# Altium schematic sheet Sheet2.SchDoc(Sheet2)
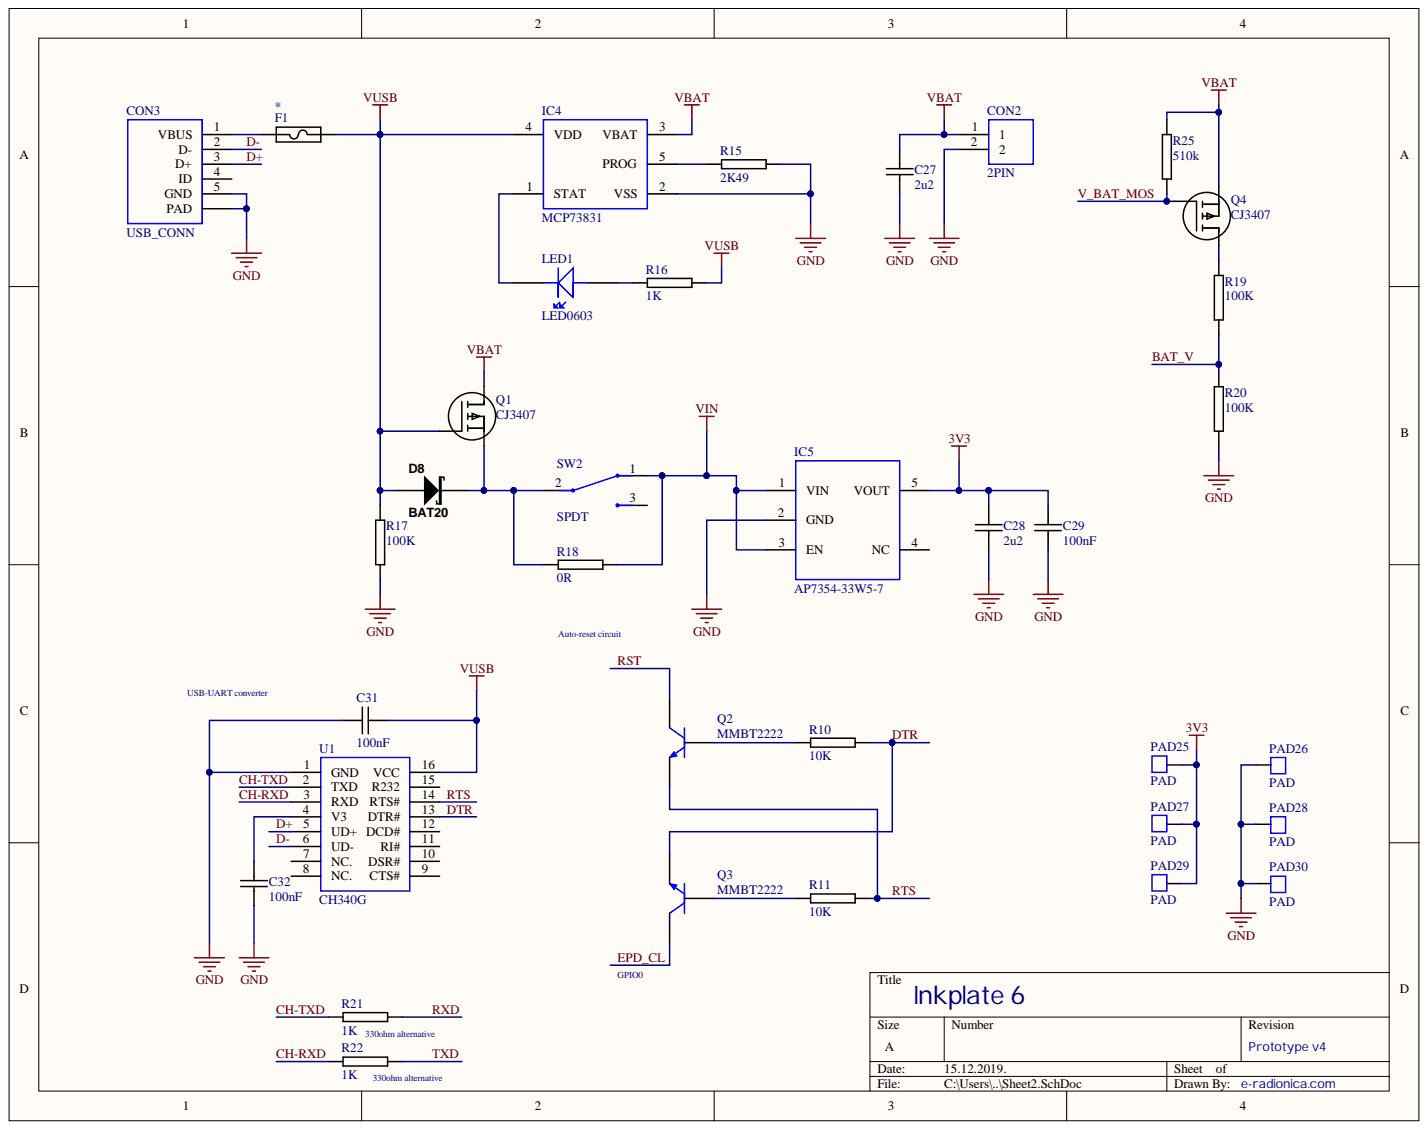
[diagram p0: Sheet2.SchDoc]

## Parts
C27  2u2  [0603C-2U2]
C28  2u2  [0603C-2U2]
C29  100nF  [0603C-GENERIC]
C31  100nF  [0603C-GENERIC]
C32  100nF  [0603C-GENERIC]
CON2  2PIN  [JST_SMD_2P]
CON3  USB_CONN  [USB_CONN]
D8  BAT20  [SOD323]
F1  (no value)
IC4  MCP73831  [SOT23-5_MCP]
IC5  AP7354-33W5-7  [SOT23-5_SE5218]
LED1  LED0603  [LED0603]
PAD25  PAD  [PAD4]
PAD26  PAD  [PAD4]
PAD27  PAD  [PAD4]
PAD28  PAD  [PAD4]
PAD29  PAD  [PAD4]
PAD30  PAD  [PAD4]
Q1  CJ3407  [SOT23-GDS]
Q2  MMBT2222  [SOT95P237X110-3N]
Q3  MMBT2222  [SOT95P237X110-3N]
Q4  CJ3407  [SOT23-GDS]
R10  10K  [0603R-10K]
R11  10K  [0603R-10K]
R15  2K49  [0603R-GENERIC]
R16  1K  [0603R-1k]
R17  100K  [0603R-100K]
R18  0R  [0603R-0R]
R19  100K  [0603R-100K]
R20  100K  [0603R-100K]
R21  1K  [0603R-1k]
R22  1K  [0603R-1k]
R25  510k  [0603R-GENERIC]
SW2  SPDT  [SPDT_SW]
U1  CH340G  [SOP16]

## Nets
3V3: C28.1 C29.1 IC5.5(VOUT) PAD25.1 PAD27.1 PAD29.1
GND: C27.2 C28.2 C29.2 C31.1 C32.2 CON2.2 CON3.5(GND) CON3.6(PAD) IC4.2(VSS) IC5.2(GND) PAD26.1 PAD28.1 PAD30.1 R15.2 R17.2 R20.2 U1.1(GND)
VBAT: C27.1 CON2.1 IC4.3(VBAT) Q1.D Q4.S R25.1
VIN: IC5.1(VIN) IC5.3(EN) R18.2 SW2.1
VUSB: C31.2 D8.A F1.2 IC4.4(VDD) Q1.G R16.2 R17.1 U1.16(VCC)
BAT_V: R19.2 R20.1
CH-RXD: R22.1 U1.3(RXD)
CH-TXD: R21.1 U1.2(TXD)
D+: CON3.3(D+) U1.5(UD+)
D-: CON3.2(D-) U1.6(UD-)
DTR: Q3.2 R10.2 U1.13(DTR#)
EPD_CL: Q3.3
RST: Q2.3
RTS: Q2.2 R11.2 U1.14(RTS#)
RXD: R21.2
TXD: R22.2
V_BAT_MOS: Q4.G R25.2
NetC32_1: C32.1 U1.4(V3)
NetCON3_1: CON3.1(VBUS) F1.1
NetD8_K: D8.K Q1.S R18.1 SW2.2
NetIC4_1: IC4.1(STAT) LED1.K
NetIC4_5: IC4.5(PROG) R15.1
NetLED1_A: LED1.A R16.1
NetQ2_1: Q2.1 R10.1
NetQ3_1: Q3.1 R11.1
NetQ4_D: Q4.D R19.1

Not connected: CON3 4(ID); IC5 4(NC); SW2 3; U1 7(NC.) 8(NC.) 9(CTS#) 10(DSR#) 11(RI#) 12(DCD#) 15(R232)

## Library data of the parts
C27 C28 = 0603C-2U2-10V-X5R-TDK: CAPACITOR 0603 CERAMIC; Manufacturer Part Number: C1608X5R1A225K; Manufacturer: TDK Corporation; Reel: C11; Supplier Part Number 1: 445-5166-2-ND; Supplier 1: Digi-Key; Library Name: vh_altium_library.intLib; Component Kind: Standard
C29 C31 C32 = 0603C-100NF-25V-X7R-TDK: CAPACITOR 0603 CERAMIC; Manufacturer Part Number: C1608X7R1E104K; Manufacturer: TDK Corporation; Reel: C12; Supplier Part Number 1: 445-1316-2-ND; Supplier 1: Digi-Key; Library Name: vh_altium_library.intLib; Component Kind: Standard
CON2 = JST_SMD_2P: Component Kind: Standard; Library Name: eink_library.IntLib
CON3 = USB_CONN: U-F-M5DD-Y-L; Component Kind: Standard; Library Name: eink_library.IntLib
D8 = BAT20-SOD323: Schottky Diode SOD323 1A 23V; Supplier Part Number 1: 497-3381-2-ND; Supplier 1: Digi-Key; Library Name: vh_altium_library.intLib; Component Kind: Standard
F1 = FUSE-GENERIC: GENERIC FUSE; Library Name: vh_altium_library.intLib; Component Kind: Standard
Q1 Q4 = BSS84: P CHANNEL MOSFET; Manufacturer Part Number: BSS84P L6327; Manufacturer: Infineon Technologies; Vgs(th) (Max) @ Id: 2V @ 20µA; Reel: Q11; Rds On (Max) @ Id, Vgs: 8 Ohm @ 170mA, 10V; Power - Max: 360mW; Input Capacitance (Ciss) @ Vds: 19pF @ 25V; Gate Charge (Qg) @ Vgs: 1.5nC @ 10V; FET Type: MOSFET P-Channel, Metal Oxide; FET Feature: Logic Level Gate; Drain to Source Voltage (Vdss): 60V; Current - Continuous Drain (Id) @ 25° C: 170mA; Supplier Part Number 1: BSS84PL6327INTR-ND; Supplier Part Number: BSS84PL6327INTR-ND; Supplier 1: Digi-Key; Supplier: Digi-Key; RoHS: RoHS Compliant; Packaging: Tape & Reel (TR); Package / Case: SOT-23; Mounting Type: Surface Mount; Library Name: vh_altium_library.intLib; Component Kind: Standard; Category: Discrete Semiconductor Products
R10 R11 = 0603R-10K: GENERIC RESISTOR 0603; Manufacturer Part Number: RMCF 1/16 10K 1% R; Manufacturer: Stackpole Electronics Inc; Tolerance: ±1%; Temperature Coefficient: ±100ppm/°C; Resistance In Ohms: 10.0K; Reel: R21; Power (Watts): 0.1W, 1/10W; Supplier Part Number 1: RMCF0603FT10K0DKR-ND; Supplier Part Number: RMCF1/1610KFRDKR-ND; Supplier 1: Digi-Key; Supplier: Digi-Key; RoHS: RoHS Compliant; Packaging: Digi-Reel®; Library Name: vh_altium_library.intLib; Lead Style: Surface Mount (SMD - SMT); Composition: Thick Film; Component Kind: Standard; Category: Resistors; Case: 0603 (1608 metric)
R15 = 0603R-2K49: GENERIC RESISTOR 0603; Manufacturer Part Number: RMCF 1/16 2.49K 1% R; Manufacturer: Stackpole Electronics Inc; Tolerance: ±1%; Temperature Coefficient: ±100ppm/°C; Resistance In Ohms: 2.49K; REEL: R83; Power (Watts): 0.1W, 1/10W; Supplier Part Number 1: RMCF0603FT2K49TR-ND; Supplier Part Number: RMCF1/162.49KFRTR-ND; Supplier 1: Digi-Key; Supplier: Digi-Key; RoHS: RoHS Compliant; Packaging: Tape & Reel (TR); Library Name: vh_altium_library.intLib; Lead Style: Surface Mount (SMD - SMT); Composition: Thick Film; Component Kind: Standard; Category: Resistors; Case: 0603 (1608 metric)
R16 R21 R22 = 0603R-1K: GENERIC RESISTOR 0603; Manufacturer Part Number: RMCF 1/16 1K 1% R; Manufacturer: Stackpole Electronics Inc; Reel: R14; Supplier Part Number 1: RMCF0603FT1K00TR-ND; Supplier 1: Digi-Key; Library Name: vh_altium_library.intLib; Component Kind: Standard
R17 R19 R20 = 0603R-100K: GENERIC RESISTOR 0603; Manufacturer Part Number: RMCF 1/16 100K 1% R; Manufacturer: Stackpole Electronics Inc; Tolerance: ±1%; Temperature Coefficient: ±100ppm/°C; REEL: R47; Power (Watts): 0.1W, 1/10W; Supplier Part Number 1: RMCF0603FT100KTR-ND; Supplier Part Number: RMCF1/16100KFRCT-ND; Supplier 1: Digi-Key; Supplier: Digi-Key; RoHS: RoHS Compliant; Resistance In Ohms: 100K; Packaging: Cut Tape (CT); Library Name: vh_altium_library.intLib; Lead Style: Surface Mount (SMD - SMT); Composition: Thick Film; Component Kind: Standard; Category: Resistors; Case: 0603 (1608 metric)
R18 = 0603R-0R: GENERIC JUMPER 0603; Manufacturer Part Number: RMC 1/16 0 G; Manufacturer: Stackpole Electronics Inc; Reel: R26; Supplier Part Number 1: RMCF0603ZT0R00TR-ND; Supplier 1: Digi-Key; Library Name: vh_altium_library.intLib; Component Kind: Standard
R25 = R0603-GENERIC: GENERIC RESISTOR 0603; Library Name: vh_altium_library.intLib; Component Kind: Standard
SW2 = ONOFF_SW: Component Kind: Standard; Library Name: eink_library.IntLib
U1 = CH340G: USB-UART converter CH340G; Component Kind: Standard; Library Name: eink_library.IntLib
IC4 = MCP73831: Component Kind: Standard; Library Name: eink_library.IntLib
IC5 = AP7354-33W5-7: Component Kind: Standard; Library Name: eink_library.IntLib
LED1 = LED0603: Component Kind: Standard; Library Name: eink_library.IntLib
PAD25-PAD30 = PAD: Component Kind: Standard; Library Name: eink_library.IntLib
Q2 Q3 = MMBT2222: Library Name: SchComponents.SchLib; Description: MMBT2222; Component Kind: Standard
